# Altium schematic sheet DIPSY_UL1K16.SchDoc(DIPSY_UL1K16)
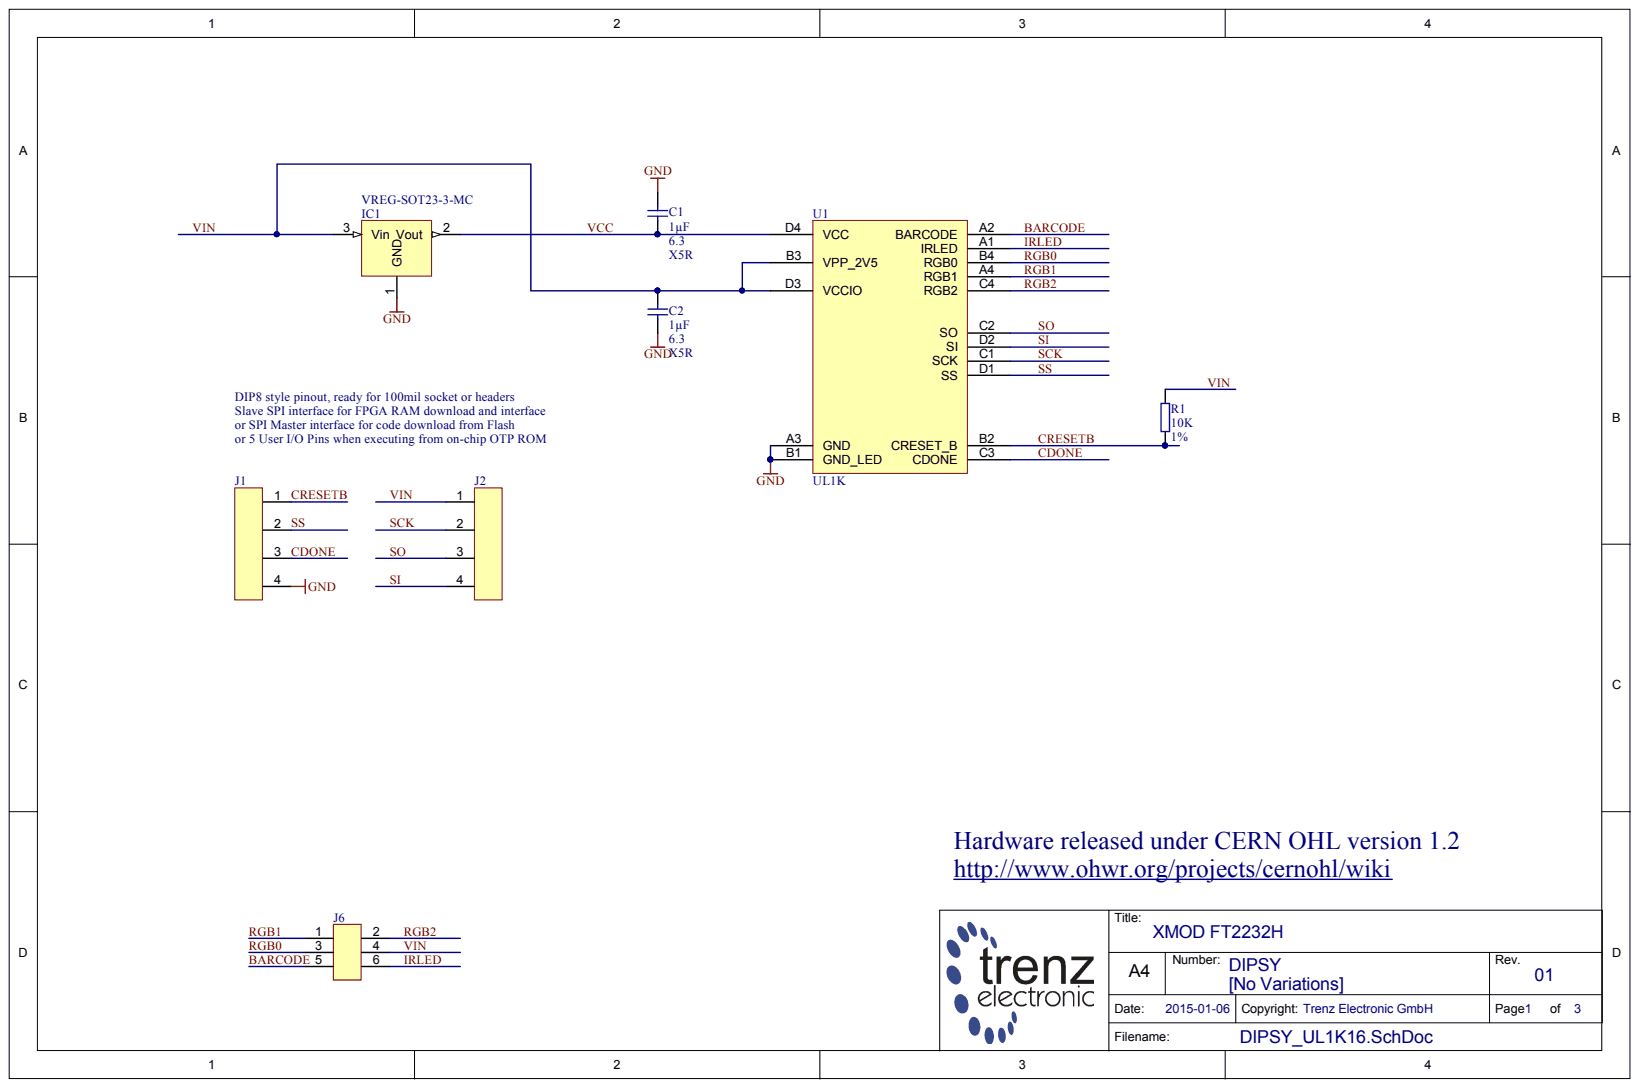
[diagram p0: DIPSY_UL1K16.SchDoc]

## Parts
C1  1µF  Technology: X5R  Voltage: 6.3  [CAPC1005X55N]
C2  1µF  Technology: X5R  Voltage: 6.3  [CAPC1005X55N]
IC1  VREG-SOT23-3-MC  [SOT23]
J1  Pin Header 2.54 1Row 4Pins  [STIFTLEISTE2_54 OG 1R-4]  (value not drawn)
J2  Pin Header 2.54 1Row 4Pins  [STIFTLEISTE2_54 OG 1R-4]  (value not drawn)
J6  Pin Header 2.54 2Row 6Pins  [STIFTLEISTE2_54 OG 2R-6]  (value not drawn)
R1  10K  Tolerance: 1%  [RESC1005X40N]
U1  UL1K  [BGA16C35P4X4_140X140X100]

## Nets
GND: C1.1 C2.2 IC1.1 J1.4 U1.A3(GND) U1.B1(GND_LED)
BARCODE: J6.5 U1.A2(BARCODE)
CDONE: J1.3 U1.C3(CDONE)
CRESETB: J1.1 R1.2 U1.B2(CRESET_B)
IRLED: J6.6 U1.A1(IRLED)
RGB0: J6.3 U1.B4(RGB0)
RGB1: J6.1 U1.A4(RGB1)
RGB2: J6.2 U1.C4(RGB2)
SCK: J2.2 U1.C1(SCK)
SI: J2.4 U1.D2(SI)
SO: J2.3 U1.C2(SO)
SS: J1.2 U1.D1(SS)
VCC: C1.2 IC1.2 U1.D4(VCC)
VIN: C2.1 IC1.3 J2.1 J6.4 R1.1 U1.B3(VPP_2V5) U1.D3(VCCIO)

## Library data of the parts
C1 C2 = 23453: KON 1µF 6.3V X5R SMD 10%; Database Table Name: C_1005_0402; Mixed: SMT; Preferred: Yes; Value: 1u; AN: 23453; Case: 1005 (0402); Component Kind: Standard; Library Name: TE.DbLib; Tolerance: 10%
IC1 = VREG-SOT23-3-MC: Database Table Name: V_REG; ID: 1; Case: SOT23; Component: VREG-SOT23-3-MC; Component Kind: Standard; Description: VREG-SOT23-3-MC; Value: VREG-SOT23-3-MC; Library Name: trioflex-cadlib.DbLib
J1 J2 = 23162: Stiftleiste gerade 1-reihig, 4pol.; Database Table Name: CON_HEADER; Mixed: THT; Trenz-Stock: Yes; AN: 23162; Component Kind: Standard; Library Name: TE.DbLib
J6 = 22334: Stiftleiste gerade 2-reihig, 6pol.; Database Table Name: CON_HEADER; Mixed: THT; Trenz-Stock: Yes; AN: 22334; Component Kind: Standard; Library Name: TE.DbLib
R1 = 22750: WID SMD 10K 1% 0,063W; Database Table Name: R_1005_0402; Mixed: SMT; Preferred: Yes; AN: 22750; Case: 1005 (0402); Component Kind: Standard; Library Name: TE.DbLib; Value: 10K
U1 = UL1K: Component Kind: Standard; Library Name: Schlib1.SchLib
